# Altium schematic sheet RECU_CAN.SchDoc(RECU_CAN)
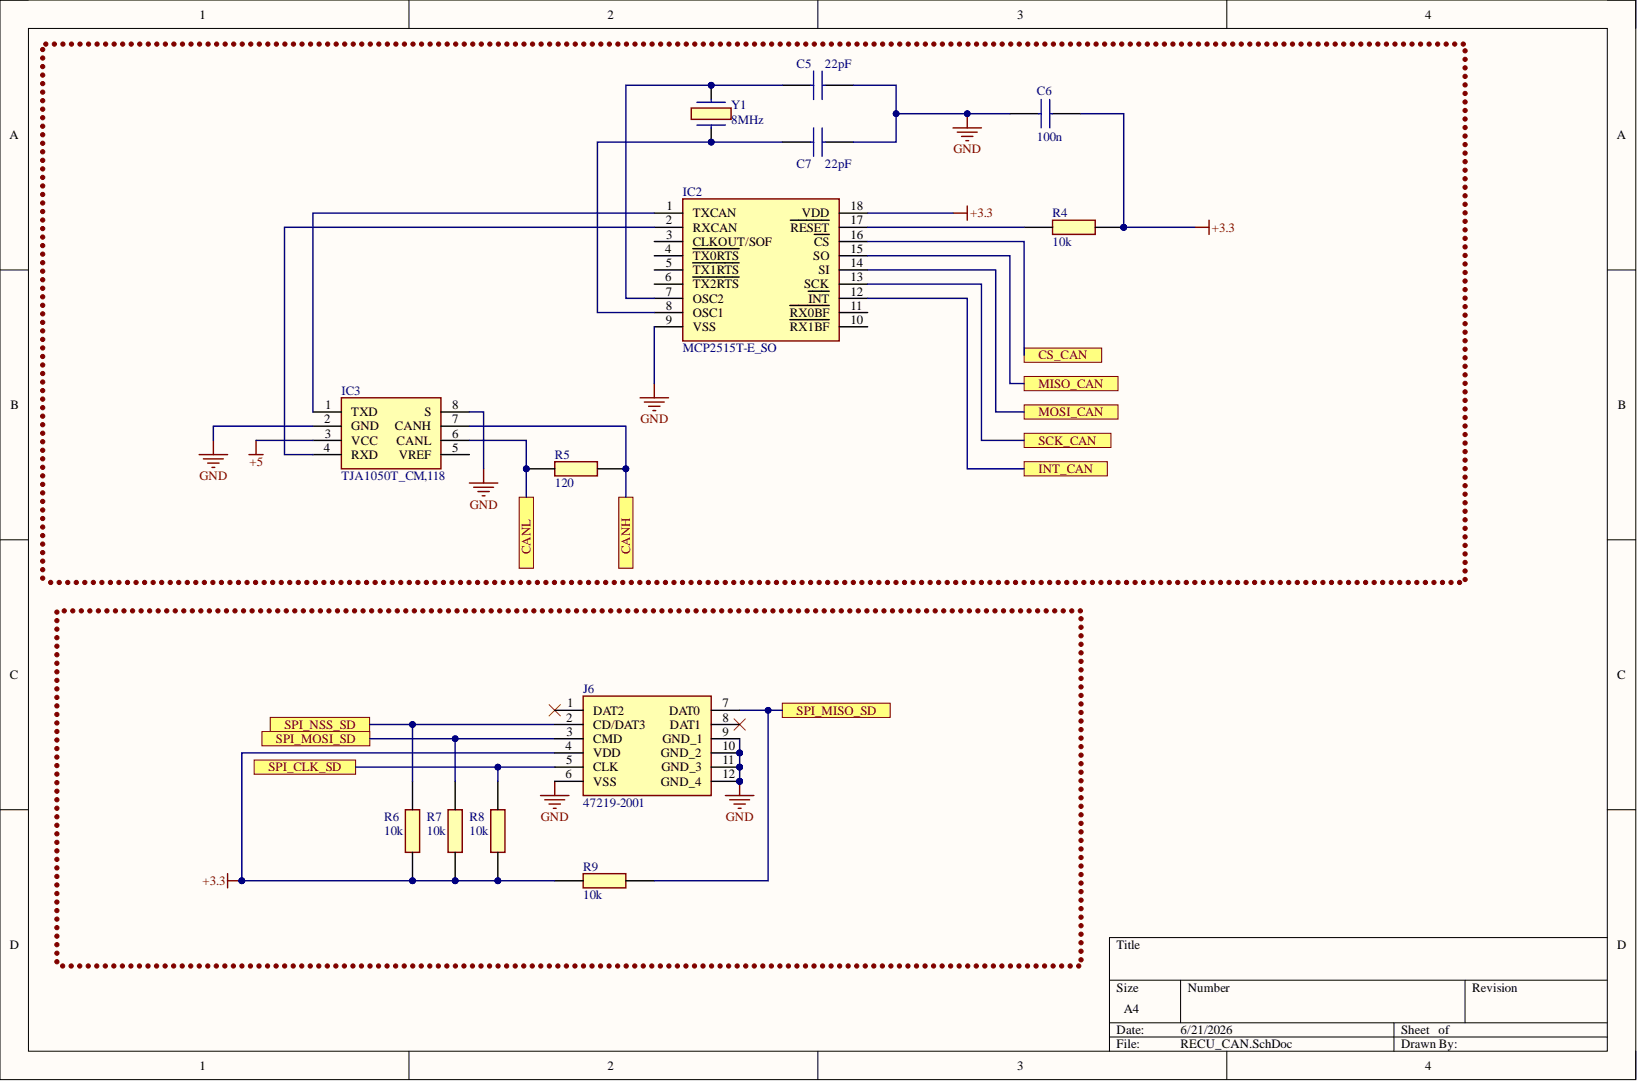
[diagram p0: RECU_CAN.SchDoc]

## Parts
C5  22pF
C6  100n
C7  22pF
IC2  MCP2515T-E_SO
IC3  TJA1050T_CM,118
J6  47219-2001
R4  10k
R5  120
R6  10k
R7  10k
R8  10k
R9  10k
Y1  8MHz

## Ports
CANH, CANL, CS_CAN, INT_CAN, MISO_CAN, MOSI_CAN, SCK_CAN, SPI_CLK_SD, SPI_MISO_SD, SPI_MOSI_SD, SPI_NSS_SD

## Nets
+3.3: C6.1 IC2.18(VDD) J6.4(VDD) R4.2 R6.2 R7.2 R8.2 R9.1
+5: IC3.3(VCC)
GND: C5.2 C6.2 C7.2 IC2.9(VSS) IC3.2(GND) IC3.8(S) J6.6(VSS) J6.9(GND_1) J6.10(GND_2) J6.11(GND_3) J6.12(GND_4)
CANH: IC3.7(CANH) R5.2 ; port CANH
CANL: IC3.6(CANL) R5.1 ; port CANL
CS_CAN: IC2.16(CS) ; port CS_CAN
INT_CAN: IC2.12(INT) ; port INT_CAN
MISO_CAN: IC2.15(SO) ; port MISO_CAN
MOSI_CAN: IC2.14(SI) ; port MOSI_CAN
SCK_CAN: IC2.13(SCK) ; port SCK_CAN
SPI_CLK_SD: J6.5(CLK) R8.1 ; port SPI_CLK_SD
SPI_MISO_SD: J6.7(DAT0) R9.2 ; port SPI_MISO_SD
SPI_MOSI_SD: J6.3(CMD) R7.1 ; port SPI_MOSI_SD
SPI_NSS_SD: J6.2(CD/DAT3) R6.1 ; port SPI_NSS_SD
NetC5_1: C5.1 IC2.7(OSC2) Y1.2
NetC7_1: C7.1 IC2.8(OSC1) Y1.1
NetIC2_1: IC2.1(TXCAN) IC3.1(TXD)
NetIC2_2: IC2.2(RXCAN) IC3.4(RXD)
NetIC2_17: IC2.17(RESET) R4.1

Not connected: IC2 3(CLKOUT/SOF) 4(TX0RTS) 5(TX1RTS) 6(TX2RTS) 10(RX1BF) 11(RX0BF); IC3 5(VREF); J6 1(DAT2) 8(DAT1)

## Library data of the parts
C5 C7 = 0805YA220JAT2A: Capacitor; Manufacturer_Name: Kyocera AVX; Component Kind: Standard; Library Name: SamacSys.SchLib; Manufacturer_Part_Number: 0805YA220JAT2A; Mouser Part Number: 581-0805YA220JAT2A; Mouser Price/Stock: https://www.mouser.co.uk/ProductDetail/KYOCERA-AVX/0805YA220JAT2A?qs=h45VL9SNQ1uLzsqNWWHBSQ%3D%3D
C6 = CL21B104KBCNNNC: Capacitor; Manufacturer_Name: SAMSUNG; Arrow Part Number: CL21B104KBCNNNC; Arrow Price/Stock: https://www.arrow.com/en/products/cl21b104kbcnnnc/samsung-electro-mechanics?region=europe; Component Kind: Standard; Datasheet Link: https://datasheet.datasheetarchive.com/originals/distributors/Datasheets_SAMA/86a3eaf0882763ef32124d5901f9b833.pdf; Height: 0.95mm; Manufacturer_Part_Number: CL21B104KBCNNNC; Mouser Part Number: 187-CL21B104KBCNNNC; Mouser Price/Stock: https://www.mouser.co.uk/ProductDetail/Samsung-Electro-Mechanics/CL21B104KBCNNNC?qs=349EhDEZ59pEfpnm5yBEmQ%3D%3D
IC2 = MCP2515T-E_SO: Integrated Circuit; Manufacturer_Name: Microchip; Manufacturer_Part_Number: MCP2515T-E/SO; Arrow Part Number: MCP2515T-E/SO; Arrow Price/Stock: https://www.arrow.com/en/products/mcp2515t-eso/microchip-technology?utm_currency=USD&region=europe; Component Kind: Standard; Datasheet Link: https://www.arrow.com/en/products/mcp2515t-eso/microchip-technology; Height: 2.65mm; Library Name: SamacSys.SchLib; Mouser Part Number: 579-MCP2515T-E/SO; Mouser Price/Stock: https://www.mouser.co.uk/ProductDetail/Microchip-Technology/MCP2515T-E-SO?qs=YUl711QUJY3%2FLJ5ChGwQqA%3D%3D
IC3 = TJA1050T_CM,118: Integrated Circuit; Manufacturer_Name: NXP; Manufacturer_Part_Number: TJA1050T/CM,118; Arrow Part Number: TJA1050T/CM,118; Arrow Price/Stock: https://www.arrow.com/en/products/tja1050tcm118/nxp-semiconductors?utm_currency=USD&region=nac; Component Kind: Standard; Datasheet Link: http://www.nxp.com/docs/en/data-sheet/TJA1050.pdf; Height: 1.75mm; Library Name: SamacSys.SchLib; Mouser Part Number: 771-TJA1050T/CM118; Mouser Price/Stock: https://www.mouser.co.uk/ProductDetail/NXP-Semiconductors/TJA1050T-CM118?qs=5XOdhvmYM0NFFspw0VP5sA%3D%3D
J6 = 47219-2001: Connector; Manufacturer_Name: Molex; Component Kind: Standard; Datasheet Link: http://www.molex.com/molex/products/datasheet.jsp?part=active/0472192001_MEMORY_CARD_SOCKET.xml&channel=Products&Lang=en-US; Manufacturer_Part_Number: 47219-2001; Mouser Part Number: 538-47219-2001; Mouser Price/Stock: https://www.mouser.co.uk/ProductDetail/Molex/47219-2001?qs=6kT3pPPHxnVaoXw6Dd7beQ%3D%3D
R4-R9 = RC0805FR-0710KL: Resistor; Manufacturer_Name: YAGEO; Arrow Part Number: RC0805FR-0710KL; Arrow Price/Stock: https://www.arrow.com/en/products/rc0805fr-0710kl/yageo?utm_currency=USD&region=europe; Component Kind: Standard; Datasheet Link: https://www.yageo.com/upload/media/product/productsearch/datasheet/rchip/PYu-RC_Group_51_RoHS_L_11.pdf; Height: 0.6mm; Manufacturer_Part_Number: RC0805FR-0710KL; Mouser Part Number: 603-RC0805FR-0710KL; Mouser Price/Stock: https://www.mouser.co.uk/ProductDetail/YAGEO/RC0805FR-0710KL?qs=7bY8808IzHq6vrU3BeiiYw%3D%3D
Y1 = 830003151: Crystal or Oscillator; Manufacturer_Name: Wurth Elektronik; Component Kind: Standard; Datasheet Link: https://www.we-online.com/catalog/datasheet/830003151.pdf; Height: 4.3mm; Library Name: SamacSys.SchLib; Manufacturer_Part_Number: 830003151; Mouser Part Number: 710-830003151; Mouser Price/Stock: https://www.mouser.co.uk/ProductDetail/Wurth-Elektronik/830003151?qs=2WXlatMagcHisY7XHApyYg%3D%3D
